# Altium schematic sheet 7_A9912FH-PCB2-AUX13V_V1.SchDoc(7_A9912FH-PCB2-AUX13V_V1)
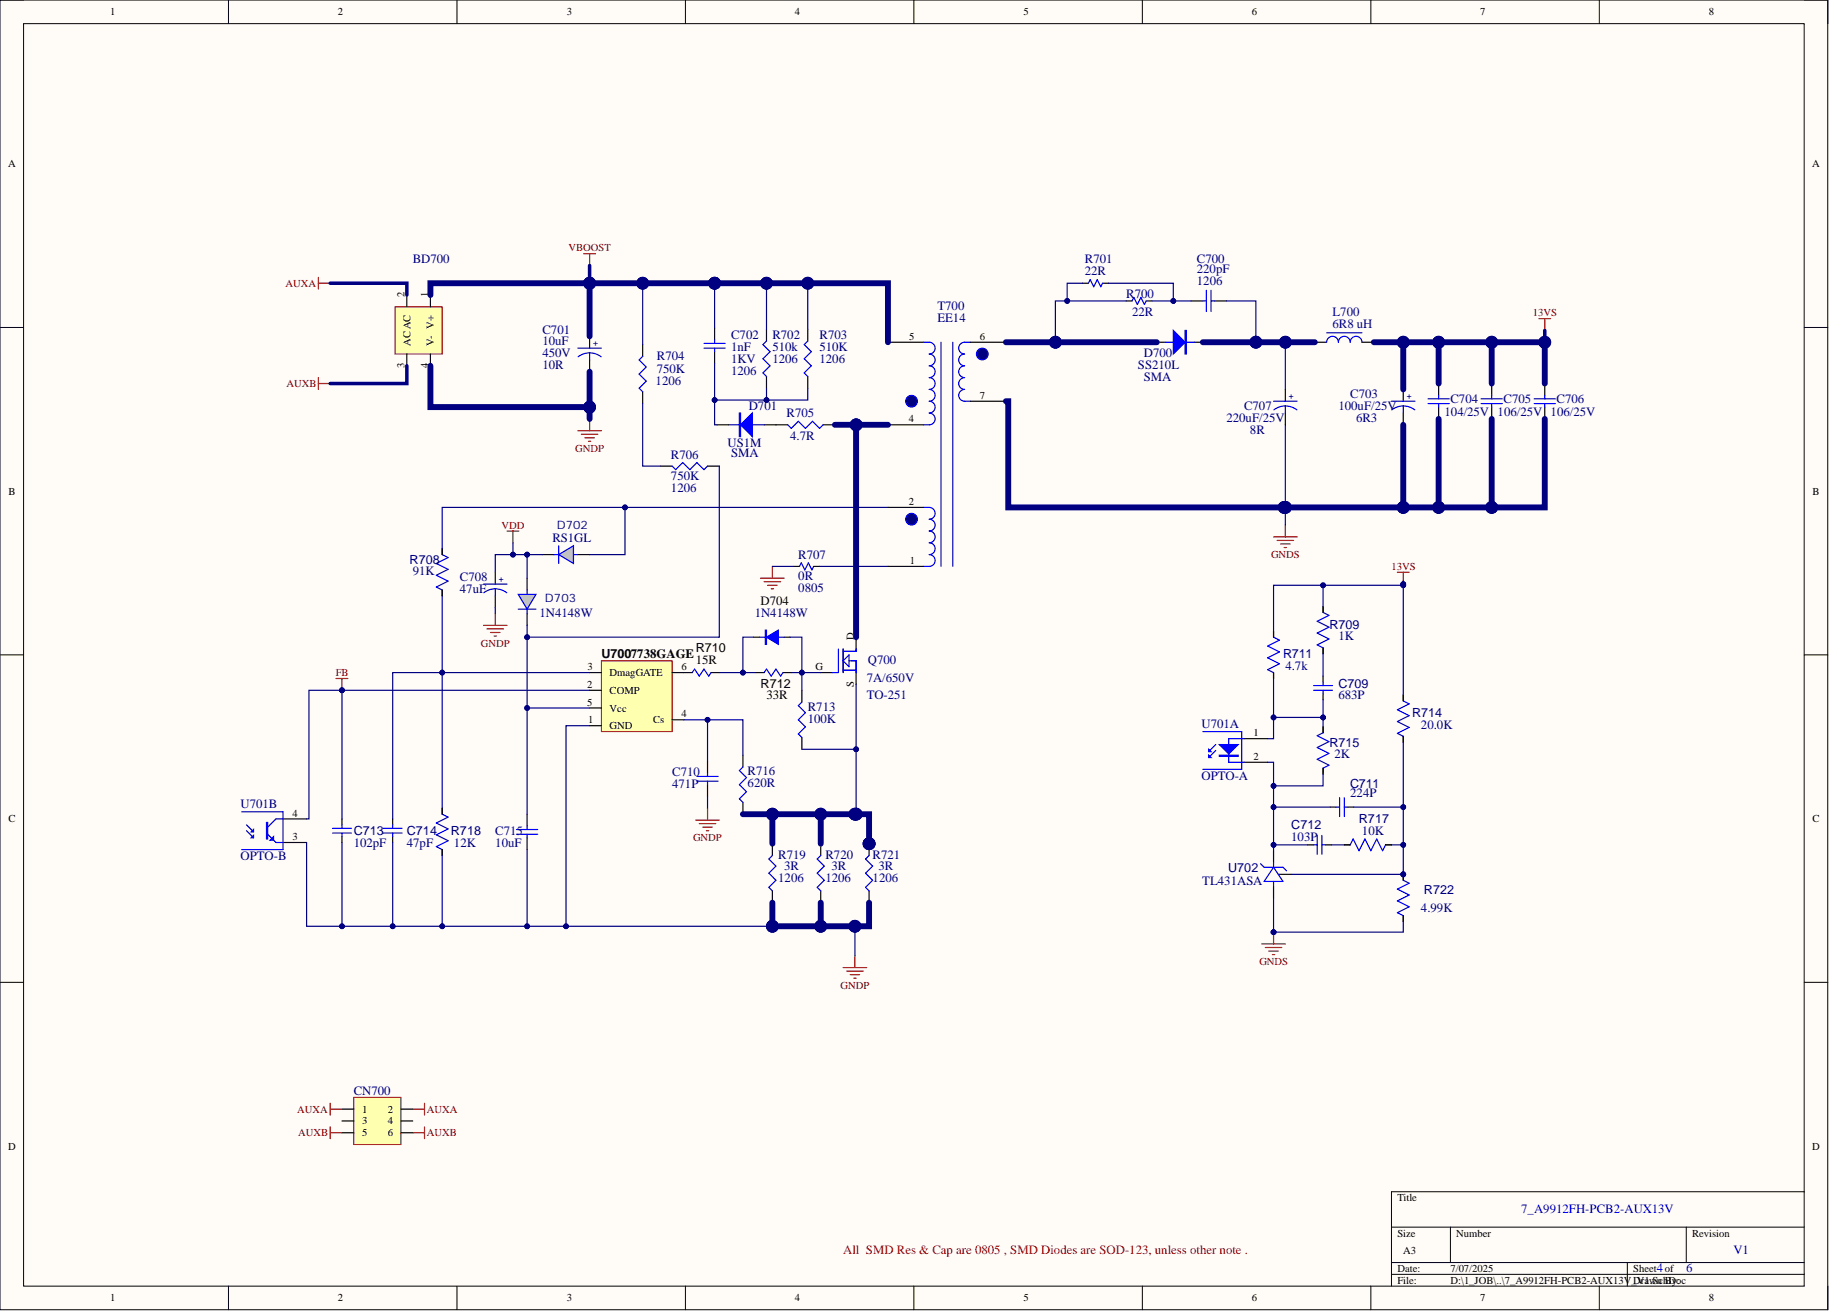
[diagram p0: 7_A9912FH-PCB2-AUX13V_V1.SchDoc]

## Parts
BD700  (no value)  [BDS-8_3X6_8-P5_1]
C700  Parameter 1: 1206  Voltage: 220pF  [CS-1206S]  (hidden comment: 250V)
C701  10uF  parameter: 10R  parameter 2: 450V  [CE-13R-P5-I]
C702  1KV  Parameter 1: 1206  Voltage: 1nF  [CS-1206S]
C703  100uF/25V  parameter 1: 6R3  [CE-5R-P2 _5-I]
C704  104/25V  [CS-0805]
C705  106/25V  [CS-0805]
C706  106/25V  [CS-0805]
C707  220uF/25V  parameter 1: 8R  [CE-8R-P3_5]
C708  47uF  [CE-5R-P2-I]
C709  683P  [CS-0805]
C710  471P  [CS-0805]
C711  224P  [CS-0805]
C712  103P  [CS-0805]
C713  102pF  [CS-0805]
C714  47pF  [CS-0805]
C715  10uF  [CS-0805]
CN700  CON6D  [CND-BH-P2_54-6H]  (value not drawn)
D700  SS210L  parameter: SMA  [DS-SMB]
D701  US1M  parameter 1: SMA  [DS-SMA]
D702  RS1GL  [DS-SOD123FL]
D703  1N4148W  [DS-SOD123FL]
D704  1N4148W  [DS-SOD123fl]
L700  6R8 uH  [LS-4_4X4]
Q700  7A/650V  parameter 1: TO-251  [MOS-TO251-GDS]
R700  22R  [RS-0805]
R701  22R  [RS-0805]
R702  510k  Parameter 1: 1206  [rs-1206s]
R703  510K  Parameter 1: 1206  [rs-1206s]
R704  750K  Parameter 1: 1206  [rs-1206s]
R705  4.7R  [rs-1206s]
R706  750K  Parameter 1: 1206  [rs-1206s]
R707  0R  Parameter 1: 0805  [RS-0805]
R708  91K  [RS-0805]
R709  1K  [RS-0805]
R710  15R  [rs-0805]
R711  4.7k  [RS-0805]
R712  33R  [rs-0805]
R713  100K  [CS-0805]
R714  20.0K  [CS-0805]
R715  2K  [RS-0805]
R716  620R  [rs-0805]
R717  10K  [CS-0805]
R718  12K  [RS-0805]
R719  3R  Parameter 1: 1206  [rs-1206s]
R720  3R  Parameter 1: 1206  [rs-1206s]
R721  3R  Parameter 1: 1206  [rs-1206s]
R722  4.99K  [CS-0805]
T700  EE14  [EE1307]
U700  7738GAGE  [IC-SOT26]
U701  OPTO-A  [IC-DIP-4]  (parts A,B)
U702  TL431ASA  [IC-TL431-SOT23-RAK]

## Nets
13VS: C703.1 C704.1 C705.1 C706.1 L700.2 R709.1 R711.1 R714.1
AUXA: BD700.2(AC) CN700.1 CN700.2
AUXB: BD700.3(AC) CN700.5 CN700.6
FB: C713.2 U700.2(COMP) U701.4
GNDP: BD700.4(V-) C701.2 C708.2 C710.1 C713.1 C714.1 C715.1 R707.2 R718.2 R719.1 R720.1 R721.1 U700.1(GND) U701.3
GNDS: C703.2 C704.2 C705.2 C706.2 C707.2 R722.2 T700.7 U702.A
VBOOST: BD700.1(V+) C701.1 C702.2 R702.2 R703.2 R704.2 T700.5
VDD: C708.1 D702.K D703.A
NetC700_1: C700.1 C707.1 D700.K L700.1
NetC700_2: C700.2 R700.2 R701.2
NetC702_1: C702.1 D701.K R702.1 R703.1
NetC709_1: C709.1 R711.2 R715.1 U701.1
NetC709_2: C709.2 R709.2
NetC710_2: C710.2 R716.2 U700.4(Cs)
NetC711_1: C711.1 R714.2 R717.2 R722.1 U702.R
NetC711_2: C711.2 C712.2 R715.2 U701.2 U702.K
NetC712_1: C712.1 R717.1
NetC714_2: C714.2 R708.2 R718.1 U700.3(Dmag)
NetC715_2: C715.2 D703.K R706.1 U700.5(Vcc)
NetD700_A: D700.A R700.1 R701.1 T700.6
NetD701_A: D701.A R705.2
NetD702_A: D702.A R708.1 T700.2
NetD704_a: D704.a Q700.G R712.2 R713.2
NetD704_k: D704.k R710.2 R712.1
NetQ700_D: Q700.D R705.1 T700.4
NetQ700_S: Q700.S R713.1 R716.1 R719.2 R720.2 R721.2
NetR704_1: R704.1 R706.2
NetR707_1: R707.1 T700.1
NetR710_1: R710.1 U700.6(GATE)

Not connected: CN700 3 4

## Library data of the parts
BD700 = BRIDGE: BD; Component Kind: Standard; Library Name: DIODE-SCH.SCHLIB
C700 = CAP: Chip capacitor. 1nF, 250V, 1206, X7R; Component Kind: Standard; Library Name: Components.SchLib
C701 C703 C707 = CAPACITOR POL: Capacitor; Component Kind: Standard
  C701: Library Name: A9911FH_V1.SCHLIB
  C703 C707: Library Name: Backup of SC_ACDC_AD_libsch.Lib
C702 = CAP: Chip capacitor. 1nF, 1KV, 1206, X7R; Component Kind: Standard; Library Name: Components.SchLib
C704-C706 = CAP: Chip capacitor. 1nF, 250V, 1206, X7R; Parameter 1: 0805; Voltage: 220pF; Component Kind: Standard; Library Name: Components.SchLib
C708 = CAPACITOR POL: Capacitor; parameter: 6R3; parameter 2: 25V; Component Kind: Standard; Library Name: A9911FH_V1.SCHLIB
C709 = C0805: Chip capacitor. 22nF, 100V, 0603, X7R; parameter: 0805; Component Kind: Standard; Library Name: SMD-R.IntLib; PCB3D: C0805(3D)
C710 = CAP: Capacitor; Component Kind: Standard; Library Name: Components.SchLib
C711 = C0805: Chip capacitor. 220pF, 25V, 0603, X7R; Voltage: 0805; Component Kind: Standard; Library Name: SMD-R.IntLib; PCB3D: C0805(3D)
C712 = C0805: Chip capacitor. 10nF, 25V, 0603, X7R; Voltage: 0805; Component Kind: Standard; Library Name: SMD-R.IntLib; PCB3D: C0805(3D)
C713 = C0805: Chip capacitor. 220pF, 50V, 0603, X7R; Component Kind: Standard; Library Name: SMD-R.IntLib; PCB3D: C0805(3D); Voltage: 50V
C714 = C0805: Chip capacitor; Voltage: 50V; Component Kind: Standard; Library Name: SMD-R.IntLib; PCB3D: C0805(3D)
C715 = CAP: Chip capacitor SMD 1u 25V X7R 0603 10%; Component Kind: Standard; Library Name: Components.SchLib; Parameter 1: 25V
D700 = DIODE: Component Kind: Standard; Description: Diode; Library Name: Components.SchLib
D701 = DIODE_1: Diode; Component Kind: Standard; Library Name: A9911FH_V1.SCHLIB
D702 D703 = Diode: Diode 100V/0.15A SOD-123; Component Kind: Standard; Library Name: SMD-R.IntLib; package: SOD123
D704 = DIODE: parameter: SOD-123; Component Kind: Standard; Description: Diode; Library Name: A060212-TD1.SCHLIB
L700 = L_COL: L; Component Kind: Standard; Library Name: COIL-SCH.SCHLIB
Q700 = MOS-N: Component Kind: Standard; Description: MOS-N; Library Name: AOEM_LIB_130805.IntLib
R700 R701 = R0805: Chip resistor, 5.1R, 1% 0805; Parameter 1: 1206; Component Kind: Standard; Library Name: T16.SchLib
R702 R703 = Res: Chip resistor, 510k, 1% 1206; Component Kind: Standard; Library Name: Components.SchLib
R704 R706 R716 = Res: Chip resistor, 5.1k, 1% 1206; Component Kind: Standard; Library Name: Components.SchLib
R705 = Res: Chip resistor, 47R, 1% 1206; Parameter 1: 0805; Component Kind: Standard; Library Name: Components.SchLib
R707 = R0805: Chip resistor, 2.2R, 1% 0805; Component Kind: Standard; Library Name: T16.SchLib
R708 = R0805: Chip resistor, 150K, 1% 0603; Component Kind: Standard; Library Name: SMD-R.IntLib; package: 0805; PCB3D: R0805(3D)
R709 = R0805: Chip resistor, 330R, 1% 0603; Component Kind: Standard; Library Name: SMD-R.IntLib; package: 0805; PCB3D: R0805(3D)
R710 R712 = R: RES; Component Kind: Standard
R711 = R0805: Chip resistor, 1k, 1% 0603; Component Kind: Standard; Library Name: SMD-R.IntLib; package: 0805; PCB3D: R0805(3D)
R713 = Res: Chip resistor, 0.45R, 1% 1206; Parameter 1: 0805; Component Kind: Standard; Library Name: Components.SchLib
R714 = R0805: Chip resistor, 76K, 1% 0603; Component Kind: Standard; Library Name: SMD-R.IntLib; package: 0805; PCB3D: R0805(3D)
R715 = R0805: Chip resistor; Component Kind: Standard; Library Name: SMD-R.IntLib; package: 0805; PCB3D: R0805(3D)
R717 = R0805: Chip resistor, 10k, 1% 0603; Component Kind: Standard; Library Name: SMD-R.IntLib; package: 0805; PCB3D: R0805(3D)
R718 = R0805: Chip resistor, 27K, 1% 0603; Component Kind: Standard; Library Name: SMD-R.IntLib; package: 0805; PCB3D: R0805(3D)
R719-R721 = Res: Chip resistor, 0.45R, 1% 1206; Component Kind: Standard; Library Name: Components.SchLib
R722 = R0805: Chip resistor, 20k, 1% 0603; Component Kind: Standard; Library Name: SMD-R.IntLib; package: 0805; PCB3D: R0805(3D)
T700 = ATQ23.7: Component Kind: Standard; Library Name: Backup of SC_ACDC_AD_libsch2021_11_28.Lib
U700 = SO-8: Component Kind: Standard
U701 = OPTO3: Optoisolator; Component Kind: Standard; Library Name: Backup of SC_ACDC_AD_libsch.Lib
U702 = TL431: Component Kind: Standard; Description: TL431; Library Name: SMD-R.IntLib; Package: SOT-23; PCB3D: QSOT23(3D)
CN700 = CON6D: Component Kind: Standard; Library Name: CONNECT-SCH.SchLib
